# Altium schematic sheet Board_Connector.SchDoc
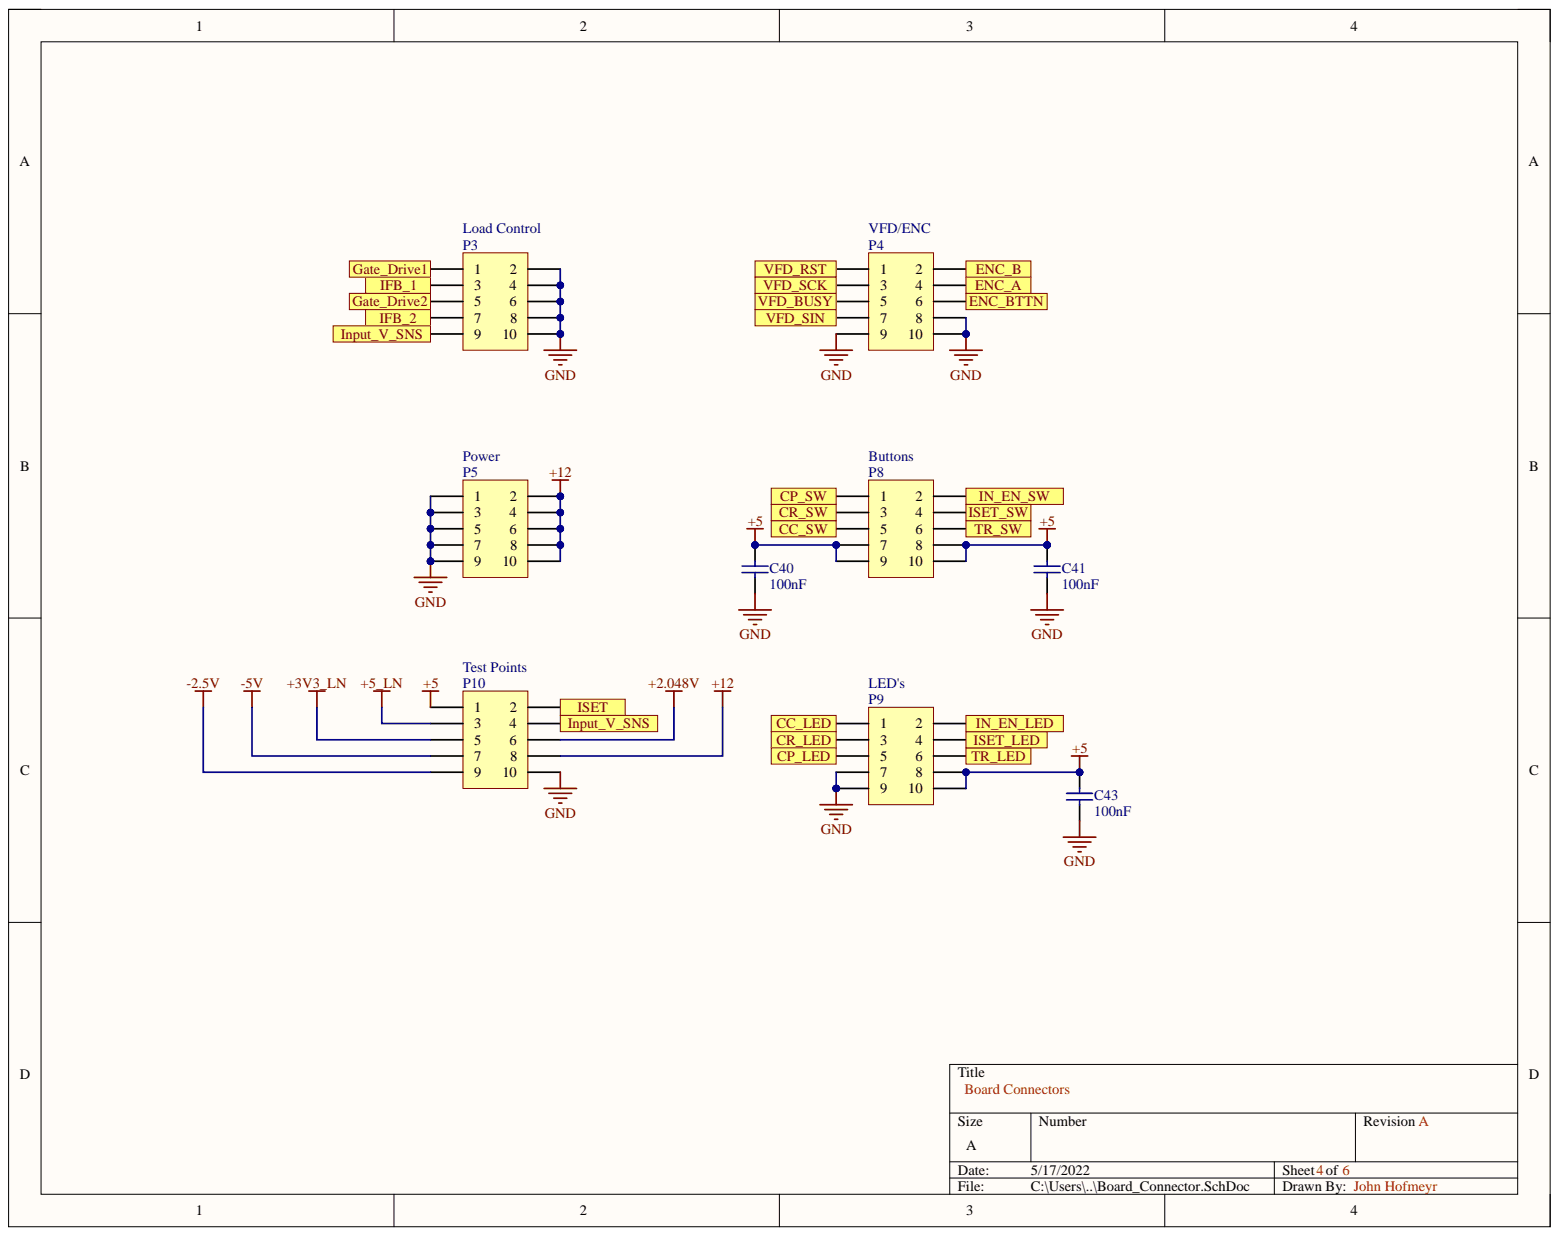
[diagram p0: Board_Connector.SchDoc]

## Parts
C40  100nF  [CAPC2013X140X50ML20T25]
C41  100nF  [CAPC2013X140X50ML20T25]
C43  100nF  [CAPC2013X140X50ML20T25]
P3  Load Control  [HDR2X5]
P4  VFD/ENC  [HDR2X5]
P5  Power  [HDR2X5]
P8  Buttons  [HDR2X5]
P9  LED's  [HDR2X5]
P10  Test Points  [HDR2X5]

## Ports
CC_LED, CC_SW, CP_LED, CP_SW, CR_LED, CR_SW, ENC_A, ENC_B, ENC_BTTN, Gate_Drive1, Gate_Drive2, IFB_1, IFB_2, IN_EN_LED, IN_EN_SW, Input_V_SNS, ISET, ISET_LED, ISET_SW, TR_LED, TR_SW, VFD_BUSY, VFD_RST, VFD_SCK, VFD_SIN

## Nets
+2.048V: P10.6
+3V3_LN: P10.5
+5: C40.2 C41.2 C43.2 P8.7 P8.8 P8.9 P8.10 P9.8 P9.10 P10.1
+5_LN: P10.3
+12: P5.2 P5.4 P5.6 P5.8 P5.10 P10.8
-2.5V: P10.9
-5V: P10.7
GND: C40.1 C41.1 C43.1 P3.2 P3.4 P3.6 P3.8 P3.10 P4.8 P4.9 P4.10 P5.1 P5.3 P5.5 P5.7 P5.9 P9.7 P9.9 P10.10
CC_LED: P9.1 ; port CC_LED
CC_SW: P8.5 ; port CC_SW
CP_LED: P9.5 ; port CP_LED
CP_SW: P8.1 ; port CP_SW
CR_LED: P9.3 ; port CR_LED
CR_SW: P8.3 ; port CR_SW
ENC_A: P4.4 ; port ENC_A
ENC_B: P4.2 ; port ENC_B
ENC_BTTN: P4.6 ; port ENC_BTTN
Gate_Drive1: P3.1 ; port Gate_Drive1
Gate_Drive2: P3.5 ; port Gate_Drive2
IFB_1: P3.3 ; port IFB_1
IFB_2: P3.7 ; port IFB_2
IN_EN_LED: P9.2 ; port IN_EN_LED
IN_EN_SW: P8.2 ; port IN_EN_SW
Input_V_SNS: P3.9 P10.4 ; port Input_V_SNS
ISET: P10.2 ; port ISET
ISET_LED: P9.4 ; port ISET_LED
ISET_SW: P8.4 ; port ISET_SW
TR_LED: P9.6 ; port TR_LED
TR_SW: P8.6 ; port TR_SW
VFD_BUSY: P4.5 ; port VFD_BUSY
VFD_RST: P4.1 ; port VFD_RST
VFD_SCK: P4.3 ; port VFD_SCK
VFD_SIN: P4.7 ; port VFD_SIN

## Library data of the parts
C40 C41 C43 = CMP-2007-04689-1: Manufacturer: AVX Interconnect / Elco; Part Number: 08055D104KAT2A; Component Kind: Standard; Library Name: Altium Content Vault
P3-P5 P8-P10 = Header 5X2: Header, 5-Pin, Dual row; Component Kind: Standard; LatestRevisionDate: 17-Jul-2002; LatestRevisionNote: Re-released for DXP Platform.; Library Name: Miscellaneous Connectors.IntLib; Signal Integrity: Connector; Publisher: Altium Limited
